# Altium schematic sheet Sheet1.SchDoc
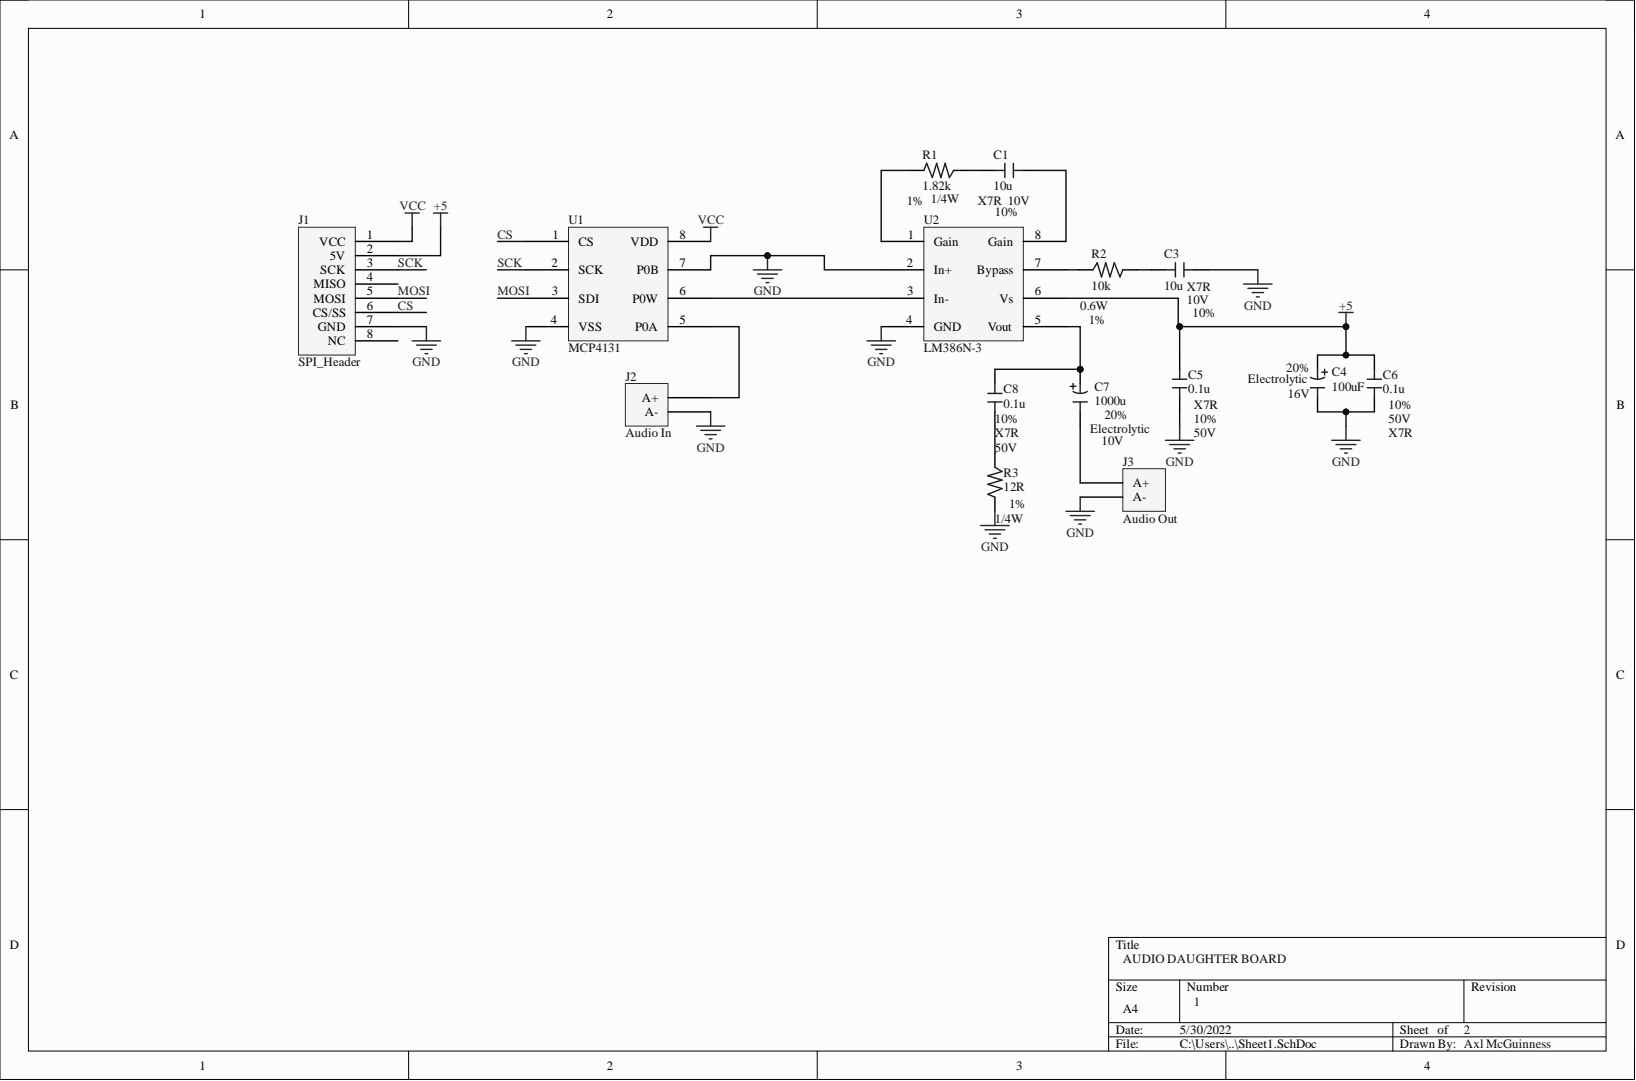
[diagram p0: Sheet1.SchDoc]

## Parts
C1  10u  Parameter 2: X7R  Parameter 3: 10V  [Capacitor]
C3  10u  Parameter 2: X7R  Parameter 3: 10V  [Capacitor]
C4  100uF  Parameter 1: 20%  Parameter 2: Electrolytic  Parameter 3: 16V  [Capacitor 100U]
C5  0.1u  Parameter 1: 10%  Parameter 2: 50V  Parameter 3: X7R  [Capacitor]
C6  0.1u  Parameter 1: 10%  Parameter 2: 50V  Parameter 3: X7R  [Capacitor]
C7  1000u  Parameter 1: 20%  Parameter 2: Electrolytic  [Capacitor 1000U]
C8  0.1u  Parameter 1: 10%  Parameter 3: X7R  [Capacitor]
J1  SPI_Header  [8-pin Male]
J2  Audio In  [PCBComponent_1 - duplicate]
J3  Audio Out  [2-Pin Male Header]
R1  1.82k  [Resistor axial]
R2  10k  [Resistor axial]
R3  12R  Parameter 1: 1%  Parameter 2: 1/4W  [Resistor axial]
U1  MCP4131  [DIP8 - duplicate]
U2  LM386N-3  [DIP8 - duplicate]

## Nets
+5: C4.2 C5.1 C6.2 J1.2(5V) U2.6(Vs)
GND: C3.1 C4.1 C5.2 C6.1 J1.7(GND) J2.2 J3.2 R3.1 U1.4(VSS) U1.7(P0B) U2.2(In+) U2.4(GND)
VCC: J1.1(VCC) U1.8(VDD)
CS: J1.6(CS/SS) U1.1(CS)
MOSI: J1.5(MOSI) U1.3(SDI)
SCK: J1.3(SCK) U1.2(SCK)
NetC1_1: C1.1 R1.2
NetC1_2: C1.2 U2.8(Gain)
NetC3_2: C3.2 R2.1
NetC7_1: C7.1 J3.1
NetC7_2: C7.2 C8.2 U2.5(Vout)
NetC8_1: C8.1 R3.2
NetJ2_1: J2.1 U1.5(P0A)
NetR1_1: R1.1 U2.1(Gain)
NetR2_2: R2.2 U2.7(Bypass)
NetU1_6: U1.6(P0W) U2.3(In-)

Not connected: J1 4(MISO) 8(NC)

## Library data of the parts
C1 C3 = Capacitor: Parameter 1: 10%; Component Kind: Standard; Library Name: Schlib1.SchLib
C4 = Polarized Cap: Component Kind: Standard; Library Name: Schlib1.SchLib
C5 C6 = Capacitor: Component Kind: Standard; Library Name: Schlib1.SchLib
C7 = Polarized Cap: Parameter 3: 10V; Component Kind: Standard; Library Name: Schlib1.SchLib
C8 = Capacitor: Parameter 2: 50V; Component Kind: Standard; Library Name: Schlib1.SchLib
J2 J3 = Audio: Component Kind: Standard; Library Name: Schlib1.SchLib
R1 = Resistor: Parameter 1: 1%; Parameter 2: 1/4W; Component Kind: Standard; Library Name: Schlib1.SchLib
R2 = Resistor: Parameter 1: 1%; Parameter 2: 0.6W; Component Kind: Standard; Library Name: Schlib1.SchLib
R3 = Resistor: Component Kind: Standard; Library Name: Schlib1.SchLib
J1 = SPI_Header: Component Kind: Standard; Library Name: Schlib1.SchLib
U1 = MCP4131: Component Kind: Standard; Library Name: Schlib1.SchLib
U2 = LM386N-3: Component Kind: Standard; Library Name: Schlib1.SchLib
